# Altium schematic sheet ltk-hall_main.SchDoc(ltk-hall_main)
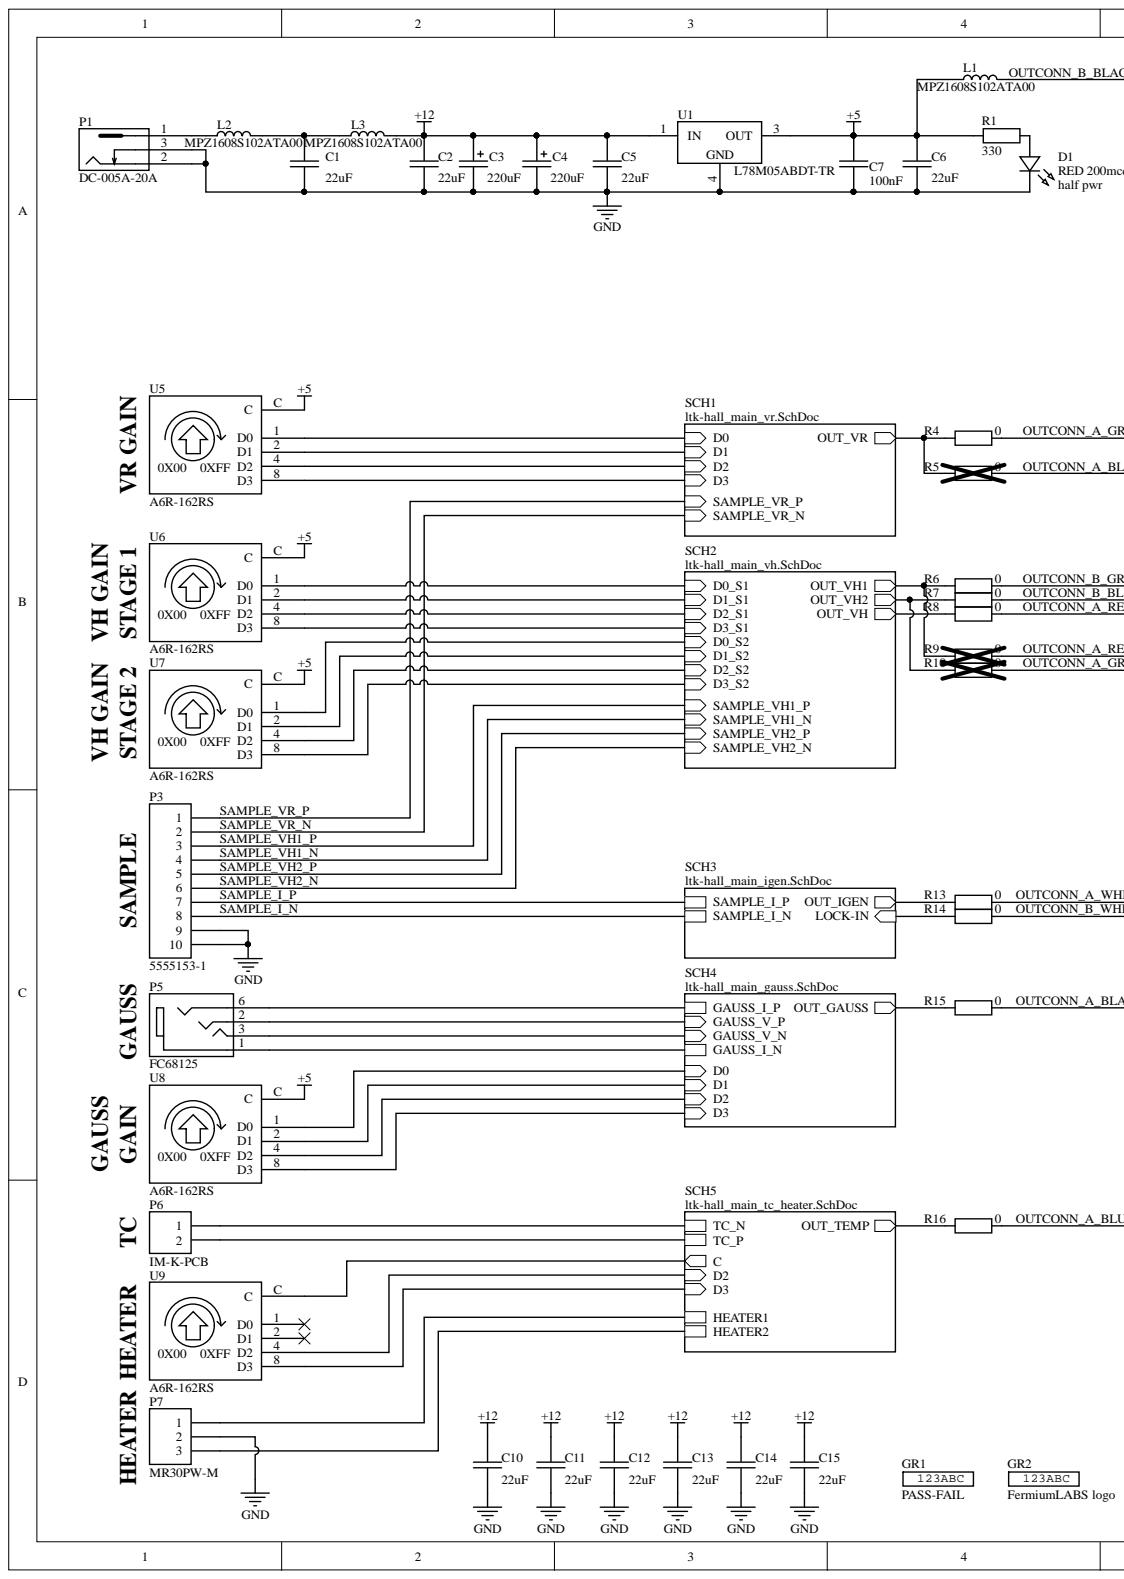
[diagram p0: ltk-hall_main.SchDoc, left]
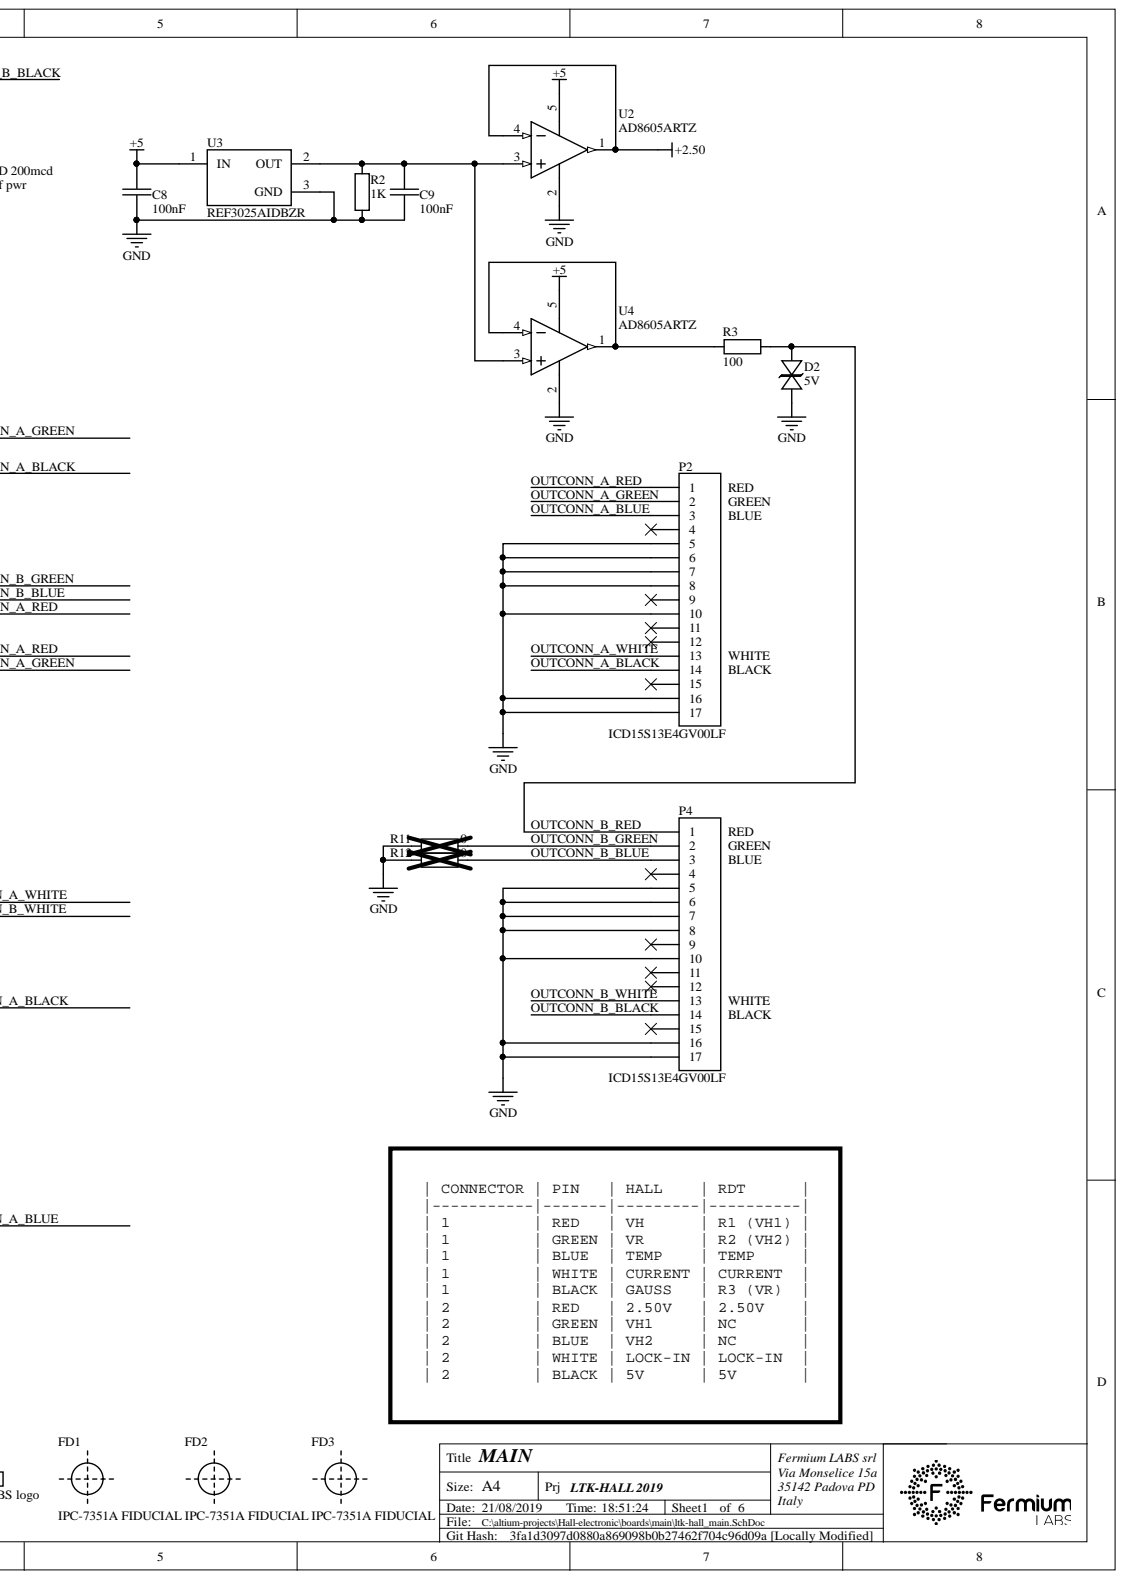
[diagram p1: ltk-hall_main.SchDoc, right]

## Parts
C1  22uF  [CAP 0805_2012]
C2  22uF  [CAP 0805_2012]
C3  220uF  [3924B366-8672-45E9-B0BE-62DACC24E783]
C4  220uF  [3924B366-8672-45E9-B0BE-62DACC24E783]
C5  22uF  [CAP 0805_2012]
C6  22uF  [CAP 0805_2012]
C7  100nF  [CAP 0603_1608]
C8  100nF  [CAP 0603_1608]
C9  100nF  [CAP 0603_1608]
C10  22uF  [CAP 0805_2012]
C11  22uF  [CAP 0805_2012]
C12  22uF  [CAP 0805_2012]
C13  22uF  [CAP 0805_2012]
C14  22uF  [CAP 0805_2012]
C15  22uF  [CAP 0805_2012]
D1  RED 200mcd  [ED49B4F6-595F-4D62-96BB-D7184CAA9B94]
D2  5V  [SOD523F]
FD1  IPC-7351A FIDUCIAL  [FIDUCIAL-IPC-7351A]  (no BOM)
FD2  IPC-7351A FIDUCIAL  [FIDUCIAL-IPC-7351A]  (no BOM)
FD3  IPC-7351A FIDUCIAL  [FIDUCIAL-IPC-7351A]  (no BOM)
GR1  PASS-FAIL  [0B1556B7-A534-4801-B57D-01EFA34B1205]  (no BOM)
GR2  FermiumLABS logo  [5394DE47-A0E8-4329-8464-7791B7C19310]  (no BOM)
L1  MPZ1608S102ATA00  [FER 0603_1608]
L2  MPZ1608S102ATA00  [FER 0603_1608]
L3  MPZ1608S102ATA00  [FER 0603_1608]
P1  DC-005A-20A  [F0972D8A-C5C0-4A84-836D-A9666EE65C2D]
P2  ICD15S13E4GV00LF  [66D8AC91-DC10-42D3-BF25-693004815827]
P3  5555153-1  [03FA2727-72E9-446E-856D-3DD573B1EBDD]
P4  ICD15S13E4GV00LF  [66D8AC91-DC10-42D3-BF25-693004815827]
P5  FC68125  [977BFFC7-4CFC-4119-A8B4-AB88E5A1DB2B]
P6  IM-K-PCB  [9044FB30-693E-4780-9B0B-95C5421D70E8]
P7  MR30PW-M  [B3F23392-877C-4EEC-B1AF-B260E8D92153]
R1  330  [RES 0603_1608]
R2  1K  [RES 0603_1608]
R3  100  [RES 0603_1608]
R4  0  [RES 0603_1608]
R5  0  [RES 0603_1608]
R6  0  [RES 0603_1608]
R7  0  [RES 0603_1608]
R8  0  [RES 0603_1608]
R9  0  [RES 0603_1608]
R10  0  [RES 0603_1608]
R11  0  [RES 0603_1608]
R12  0  [RES 0603_1608]
R13  0  [RES 0603_1608]
R14  0  [RES 0603_1608]
R15  0  [RES 0603_1608]
R16  0  [RES 0603_1608]
U1  L78M05ABDT-TR  [4BDA507D-BB70-4009-B963-85AD90086B51]
U2  AD8605ARTZ  [A3DDA0BC-073E-4B18-9613-F713A7EC1E27]
U3  REF3025AIDBZR  [TI SOT-23-3 DBZ]
U4  AD8605ARTZ  [A3DDA0BC-073E-4B18-9613-F713A7EC1E27]
U5  A6R-162RS  [2599C238-5645-4A8C-8BF9-C7515FACCFA0]
U6  A6R-162RS  [2599C238-5645-4A8C-8BF9-C7515FACCFA0]
U7  A6R-162RS  [2599C238-5645-4A8C-8BF9-C7515FACCFA0]
U8  A6R-162RS  [2599C238-5645-4A8C-8BF9-C7515FACCFA0]
U9  A6R-162RS  [2599C238-5645-4A8C-8BF9-C7515FACCFA0]

## Nets
+2.50: U2.1 U2.4
+5: C6.1 C7.1 C8.1 L1.1 R1.1 U1.3 U2.5 U3.1 U4.5 U5.C U6.C U7.C U8.C
+12: C2.1 C3.1 C4.1 C5.1 C10.1 C11.1 C12.1 C13.1 C14.1 C15.1 L3.2 U1.1
GND: C1.2 C2.2 C3.2 C4.2 C5.2 C6.2 C7.2 C8.2 C9.2 C10.2 C11.2 C12.2 C13.2 C14.2 C15.2 D1.1 D2.2 P1.2 P1.3 P2.5 P2.6 P2.7 P2.8 P2.10 P2.16 P2.17 P3.9 P3.10 P4.5 P4.6 P4.7 P4.8 P4.10 P4.16 P4.17 P7.2 R2.1 R11.1 R12.1 U1.4 U2.2 U3.3 U4.2
OUTCONN_A_BLACK: P2.14 R5.2 R15.2
OUTCONN_A_BLUE: P2.3 R16.2
OUTCONN_A_GREEN: P2.2 R4.2 R10.2
OUTCONN_A_RED: P2.1 R8.2 R9.2
OUTCONN_A_WHITE: P2.13 R13.2
OUTCONN_B_BLACK: L1.2 P4.14
OUTCONN_B_BLUE: P4.3 R7.2 R12.2
OUTCONN_B_GREEN: P4.2 R6.2 R11.2
OUTCONN_B_RED: D2.1 P4.1 R3.2
OUTCONN_B_WHITE: P4.13 R14.2
SAMPLE_I_N: P3.8
SAMPLE_I_P: P3.7
SAMPLE_VH1_N: P3.4
SAMPLE_VH1_P: P3.3
SAMPLE_VH2_N: P3.6
SAMPLE_VH2_P: P3.5
SAMPLE_VR_N: P3.2
SAMPLE_VR_P: P3.1
NetC1_1: C1.1 L2.2 L3.1
NetC9_1: C9.1 R2.2 U2.3 U3.2 U4.3
NetD1_2: D1.2 R1.2
NetL2_1: L2.1 P1.1
NetP2_4: P2.4
NetP2_9: P2.9
NetP2_11: P2.11
NetP2_12: P2.12
NetP2_15: P2.15
NetP4_4: P4.4
NetP4_9: P4.9
NetP4_11: P4.11
NetP4_12: P4.12
NetP4_15: P4.15
NetP5_1: P5.1
NetP5_2: P5.2
NetP5_3: P5.3
NetP5_6: P5.6
NetP6_1: P6.1
NetP6_2: P6.2
NetP7_1: P7.1
NetP7_3: P7.3
NetR3_1: R3.1 U4.1 U4.4
NetR4_1: R4.1 R5.1
NetR6_1: R6.1 R9.1
NetR7_1: R7.1 R10.1
NetR8_1: R8.1
NetR13_1: R13.1
NetR14_1: R14.1
NetR15_1: R15.1
NetR16_1: R16.1
NetU5_1: U5.1(D0)
NetU5_2: U5.2(D1)
NetU5_4: U5.4(D2)
NetU5_8: U5.8(D3)
NetU6_1: U6.1(D0)
NetU6_2: U6.2(D1)
NetU6_4: U6.4(D2)
NetU6_8: U6.8(D3)
NetU7_1: U7.1(D0)
NetU7_2: U7.2(D1)
NetU7_4: U7.4(D2)
NetU7_8: U7.8(D3)
NetU8_1: U8.1(D0)
NetU8_2: U8.2(D1)
NetU8_4: U8.4(D2)
NetU8_8: U8.8(D3)
NetU9_1: U9.1(D0)
NetU9_2: U9.2(D1)
NetU9_4: U9.4(D2)
NetU9_8: U9.8(D3)
NetU9_C: U9.C

## Library data of the parts
C1 C2 C5 C6 C10-C15 = 4e18e584-a077-4dfe-b9da-5e528e787323: CAP SMD MLCC 22uF 25V 0805 X5R; Manufacturer Part Number 1: CL21A106KAYNNNE; Manufacturer 1: Samsung Electro-Mechanics; SPN 2: C84416; SPN 1: C15850; S 2: LCSC; id: 14817; Database Table Name: public.lateraldb; Value: 22uF; S 1: LCSC; Part Number: 4e18e584-a077-4dfe-b9da-5e528e787323; Manufacturer Part Number 2: GRM21BR61E106KA73L; Manufacturer 2: Murata; Component Kind: Standard; Library Name: frmdblib.DbLib
C3 C4 = 1a28df19-6d3e-4a71-836c-f22920f8e8ab: CAP  THT ELEC 220uF 25V 20%; Manufacturer Part Number 1: 25PK220MEFC-6.3*11; Manufacturer 1: Rubycon; SPN 1: C109337; S 1: LCSC; id: 14782; Database Table Name: public.lateraldb; Value: 220uF; Part Number: 1a28df19-6d3e-4a71-836c-f22920f8e8ab; ComponentLink1URL: http://localhost:8080/static/files/Rubycon-220uF-25V_C109337_12019-03-10_214953.757848.pdf?Rubycon-220uF-25V_C109337 (1).pdf; ComponentLink1Description: Datasheet; Component Kind: Standard; Library Name: frmdblib.DbLib
C7-C9 = 02841f84-ac15-41b5-9e17-915f8f067828: CAP SMD MLCC 100nF 25V 0603 X7R; Manufacturer Part Number 1: CL10B104KA8NNNC; Manufacturer 1: Samsung Electro-Mechanics; SPN 1: C1590; S 1: LCSC; id: 14784; Database Table Name: public.lateraldb; Value: 100nF; Part Number: 02841f84-ac15-41b5-9e17-915f8f067828; ComponentLink1URL: http://localhost:8080/static/files/Samsung-Electro-Mechanics-CL10B104KA8NNNC_C15902019-03-10_215548.826887.pdf?Samsung-Electro-Mechanics-CL10B104KA8NNNC_C1590.pdf; ComponentLink1Description: Datasheet; Component Kind: Standard; Library Name: frmdblib.DbLib
D1 = c65ae3d2-9539-4634-8979-616a331b7494: DIODE LED THT 5MM RED 200mcd; Manufacturer Part Number 1: 333-2SURD/S530-A3-L; Manufacturer 1: Everlight; SPN 1: C99758; S 1: LCSC; id: 14851; Database Table Name: public.lateraldb; Value: RED 200mcd; Part Number: c65ae3d2-9539-4634-8979-616a331b7494; ComponentLink1URL: http://localhost:8080/static/files/Everlight-Elec-333-2SURD-S530-A3-L_C997582019-08-18_181624.501044.pdf?Everlight-Elec-333-2SURD-S530-A3-L_C99758.pdf; ComponentLink1Description: Datasheet; Component Kind: Standard; Library Name: frmdblib.DbLib
D2 = daa58212-38e2-4326-a156-8e48058c46f1: DIODE SMD TVS 5V BIDIRECTIONAL SOD-523; Manufacturer Part Number 1: PESD5V0S1BBN; Manufacturer 1: Born Semiconductor; SPN 2: C314264; SPN 1: C97640; S 2: LCSC; id: 14837; Database Table Name: public.lateraldb; Value: 5V; S 1: LCSC; Part Number: daa58212-38e2-4326-a156-8e48058c46f1; Manufacturer Part Number 2: PESD5V0S1BB,115; Manufacturer 2: Nexperia; ComponentLink2URL: http://localhost:8080/static/files/1901051701_Bourne-Semicon-Shenzhen-PESD5V0S1BBN_C3142642019-08-16_201911.992552.pdf?1901051701_Bourne-Semicon-Shenzhen-PESD5V0S1BBN_C314264.pdf; ComponentLink2Description: Datasheet; ComponentLink1URL: http://localhost:8080/static/files/Nexperia-PESD5V0S1BB-115_C976402019-08-16_201905.624024.pdf?Nexperia-PESD5V0S1BB-115_C97640.pdf; ComponentLink1Description: Datasheet; Component Kind: Standard; Library Name: frmdblib.DbLib
FD1-FD3 = 19da4612-eb95-43bb-9984-553a52b2a224: GRAPHICS FIDUCIAL IPC-7351A; id: 14800; Database Table Name: public.lateraldb; Part Number: 19da4612-eb95-43bb-9984-553a52b2a224; Library Name: frmdblib.DbLib
GR1 = d1abe19f-3fed-4f20-b2ed-afc0ffbc6628: GRAPHICS PASS-FAIL MARK; id: 14801; Database Table Name: public.lateraldb; Part Number: d1abe19f-3fed-4f20-b2ed-afc0ffbc6628; Library Name: frmdblib.DbLib
GR2 = 50f8ea88-0034-49fe-a263-d8e97bc35e2f: GRAPHICS LOGO FERMIUM LABS SRL; id: 14799; Database Table Name: public.lateraldb; Part Number: 50f8ea88-0034-49fe-a263-d8e97bc35e2f; Library Name: frmdblib.DbLib
L1-L3 = a4b2e015-bf25-47b8-954c-a5b040744a3a: FER SMD CHIP 0603 800mA 1K@100MHz 300mOhm; Manufacturer 1: TDK; SPN 1: C76814; S 1: LCSC; id: 14850; Database Table Name: public.lateraldb; Part Number: a4b2e015-bf25-47b8-954c-a5b040744a3a; Manufacturer Part Number 1: MPZ1608S102ATA00; ComponentLink1URL: http://localhost:8080/static/files/TDK-MPZ1608S102ATA00_C768142019-08-18_021255.210641.pdf?TDK-MPZ1608S102ATA00_C76814.pdf; ComponentLink1Description: Datasheet; Component Kind: Standard; Library Name: frmdblib.DbLib
P1 = b53db51a-1725-412b-9039-fa7a45c7c438: CONN THT DC JACK 0.5A 30VDC 5Kcycles; Manufacturer 1: HRO Electronics; SPN 1: C191049; S 1: LCSC; id: 14780; Database Table Name: public.lateraldb; Part Number: b53db51a-1725-412b-9039-fa7a45c7c438; Manufacturer Part Number 1: DC-005A-20A; ComponentLink1URL: http://localhost:8080/static/files/Korean-Hroparts-Elec-DC-005A-20A_C191049_22019-03-10_214231.486078.pdf?Korean-Hroparts-Elec-DC-005A-20A_C191049 (2).pdf; ComponentLink1Description: Datasheet; Component Kind: Standard; Library Name: frmdblib.DbLib
P2 P4 = af155709-b835-40ac-b9b5-4a44b0fd2777: CONN D-SUB HD 15POLES BLACK THT; Manufacturer 1: FCI; S 1: TME; id: 14838; Database Table Name: public.lateraldb; SPN 1: ICD15S13E4GV00LF; Part Number: af155709-b835-40ac-b9b5-4a44b0fd2777; Manufacturer Part Number 1: ICD15S13E4GV00LF; ComponentLink2URL: http://localhost:8080/static/files/io_dsub_highdensity2019-08-16_203112.582695.pdf?io_dsub_highdensity.pdf; ComponentLink2Description: Datasheet; ComponentLink1URL: http://localhost:8080/static/files/c-cd-00112019-08-16_203054.958624.pdf?c-cd-0011.pdf; ComponentLink1Description: Mechanical Drawing; Component Kind: Standard; Library Name: frmdblib.DbLib
P3 = f401b84a-9695-45b1-951e-00bef0039069: CONN RJ45 SHIELDED; Manufacturer 1: TE Connectivity; SPN 1: C125072; S 1: LCSC; id: 14843; Database Table Name: public.lateraldb; Part Number: f401b84a-9695-45b1-951e-00bef0039069; Manufacturer Part Number 1: 5555153-1; ComponentLink3URL: http://localhost:8080/static/files/ENG_CVM_CVM_5555153-1_C.3d_stp2019-08-17_152716.824354.zip?ENG_CVM_CVM_5555153-1_C.3d_stp.zip; ComponentLink3Description: 3D Model; ComponentLink2URL: http://localhost:8080/static/files/TE-Connectivity-5555153-1_C1250722019-08-17_152708.984028.pdf?TE-Connectivity-5555153-1_C125072.pdf; ComponentLink2Description: Datasheet; ComponentLink1URL: https://www.te.com/usa-en/product-5555153-1.html?te_campaign=oct_glo_manufacturer&elqCampaignId=26136&te_bu=Cor&te_type=other; ComponentLink1Description: Product Page; Component Kind: Standard; Library Name: frmdblib.DbLib
P5 = 3e3c57e9-f1b8-4c12-8bb1-97da9eb9c220: CONN JACK 3.5MM 4POLE THT; Manufacturer 1: Cliff; S 1: TME; id: 14844; Database Table Name: public.lateraldb; SPN 1: FC68125; Part Number: 3e3c57e9-f1b8-4c12-8bb1-97da9eb9c220; Manufacturer Part Number 1: FC68125; ComponentLink1URL: http://localhost:8080/static/files/Cliff-FC68125-datasheet2019-08-17_161810.238588.pdf?Cliff-FC68125-datasheet.pdf; ComponentLink1Description: Datasheet; Component Kind: Standard; Library Name: frmdblib.DbLib
P6 = 44088476-53fa-4578-a611-1fd63affe7b4: CONN THERMOCOUPLE K-TYPE THT; Manufacturer 1: Labfacility; SPN 1: 3810628; S 1: Farnell; id: 14845; Database Table Name: public.lateraldb; Part Number: 44088476-53fa-4578-a611-1fd63affe7b4; Manufacturer Part Number 1: IM-K-PCB; ComponentLink1URL: http://localhost:8080/static/files/28420972019-08-17_162400.037613.pdf?2842097.pdf; ComponentLink1Description: Datasheet; Component Kind: Standard; Library Name: frmdblib.DbLib
P7 = 8565bfd1-7a71-455a-a363-4ce9dda60de6: CONN DC 3 POLE 15A PCB MALE; Manufacturer 1: Changzhou Amass Elec; S 1: TME; id: 14833; Database Table Name: public.lateraldb; SPN 1: MR30PW-M; Part Number: 8565bfd1-7a71-455a-a363-4ce9dda60de6; Manufacturer Part Number 1: MR30PW-M; ComponentLink2URL: http://localhost:8080/static/files/B3F23392-877C-4EEC-B1AF-B260E8D921532019-08-16_190154.620416.step?B3F23392-877C-4EEC-B1AF-B260E8D92153.step; ComponentLink2Description: 3D Model; ComponentLink1URL: http://localhost:8080/static/files/MR30PW_SPEC2019-08-16_190138.191607.pdf?MR30PW SPEC.pdf; ComponentLink1Description: Datasheet; Component Kind: Standard; Library Name: frmdblib.DbLib
R1 = e8555d7b-eeda-4727-b2e1-550cdc03d819: RES SMD 330 OHM 1% 1/10W 0603; Manufacturer Part Number 1: RC0603FR-07330RL; Manufacturer 1: Yageo; SPN 1: C105881; S 1: LCSC; id: 12350; Database Table Name: public.lateraldb; Value: 330; Part Number: e8555d7b-eeda-4727-b2e1-550cdc03d819; Component Kind: Standard; Library Name: frmdblib.DbLib
R2 = a678220f-9e9d-4863-a833-9993504f723c: RES SMD 1K OHM 1% 1/10W 0603; Manufacturer Part Number 1: RC0603FR-071KL; Manufacturer 1: Yageo; SPN 1: C22548; S 1: LCSC; id: 14794; Database Table Name: public.lateraldb; Value: 1K; Part Number: a678220f-9e9d-4863-a833-9993504f723c; Component Kind: Standard; Library Name: frmdblib.DbLib
R3 = 0a6c4b74-f837-4563-b154-6e196d753525: RES SMD 100 OHM 1% 1/10W 0603; Manufacturer Part Number 1: RC0603FR-07100RL; Manufacturer 1: Yageo; SPN 1: C105588; S 1: LCSC; id: 12301; Database Table Name: public.lateraldb; Value: 100; Part Number: 0a6c4b74-f837-4563-b154-6e196d753525; Component Kind: Standard; Library Name: frmdblib.DbLib
R4-R16 = 6ae227f9-847f-4766-8522-e11a83531204: RES SMD 0 OHM 5% 1/10W 0603; Manufacturer Part Number 1: RC0603JR-070RL; Manufacturer 1: Yageo; SPN 1: C95177; S 1: LCSC; id: 11973; Database Table Name: public.lateraldb; Value: 0; Part Number: 6ae227f9-847f-4766-8522-e11a83531204; Component Kind: Standard; Library Name: frmdblib.DbLib
U1 = 446536aa-1bdd-4764-8cae-49f4e0c126ff: IC SMD LINEAR VOLTAGE REGULATOR 5V 500mA DPAK/TO-252; Manufacturer 1: ST Microelectronics; SPN 1: C58069; S 1: LCSC; id: 14848; Database Table Name: public.lateraldb; Part Number: 446536aa-1bdd-4764-8cae-49f4e0c126ff; Manufacturer Part Number 1: L78M05ABDT-TR; ComponentLink1URL: http://localhost:8080/static/files/STMicroelectronics-L78M05ABDT-TR_C580692019-08-17_184424.026041.pdf?STMicroelectronics-L78M05ABDT-TR_C58069.pdf; ComponentLink1Description: Datasheet; Component Kind: Standard; Library Name: frmdblib.DbLib
U2 U4 = 5610e9ea-bca8-49b2-8e5f-11409231f6a4: IC OPAMP 65uV 1pA RRIO 5V 80mA SOT-23-5; Manufacturer 1: Analog Devices; SPN 1: C9641; S 1: LCSC; id: 14830; Database Table Name: public.lateraldb; Part Number: 5610e9ea-bca8-49b2-8e5f-11409231f6a4; Manufacturer Part Number 1: AD8605ARTZ; ComponentLink1URL: http://localhost:8080/static/files/Analog_Devices-AD8605ARTZ-REEL7-datasheet2019-08-16_175825.352099.pdf?Analog_Devices-AD8605ARTZ-REEL7-datasheet.pdf; ComponentLink1Description: Datasheet; Component Kind: Standard; Library Name: frmdblib.DbLib
U3 = 0de0df07-6dfd-42d8-9fe7-171c27772811: IC VOLTAGE REF SERIES 2.5V 75ppm 0.2% SOT-23; Manufacturer 1: Texas Instruments; SPN 1: C11334; S 1: LCSC; id: 14831; Database Table Name: public.lateraldb; Part Number: 0de0df07-6dfd-42d8-9fe7-171c27772811; Manufacturer Part Number 1: REF3025AIDBZR; ComponentLink1URL: http://localhost:8080/static/files/Texas-Instruments-TI-REF3025AIDBZR_C113342019-08-16_180357.548624.pdf?Texas-Instruments-TI-REF3025AIDBZR_C11334.pdf; ComponentLink1Description: Datasheet; Component Kind: Standard; Library Name: frmdblib.DbLib
U5-U9 = bbf157ad-cd7a-4e82-97e3-d6a037590888: SWITCH ROTARY HEX/BCD 16 POSITIONS; Manufacturer 1: Omron; S 1: TME; id: 14834; Database Table Name: public.lateraldb; SPN 1: A6R-162RS; Part Number: bbf157ad-cd7a-4e82-97e3-d6a037590888; Manufacturer Part Number 1: A6R-162RS; ComponentLink2URL: http://localhost:8080/static/files/2599C238-5645-4A8C-8BF9-C7515FACCFA02019-08-16_192704.487115.step?2599C238-5645-4A8C-8BF9-C7515FACCFA0.step; ComponentLink2Description: 3D Model; ComponentLink1URL: http://localhost:8080/static/files/A6R-A6RV2019-08-16_190638.241287.PDF?A6R-A6RV.PDF; ComponentLink1Description: Datasheet; Component Kind: Standard; Library Name: frmdblib.DbLib
